# Altium schematic sheet COLLAUDO.SchDoc
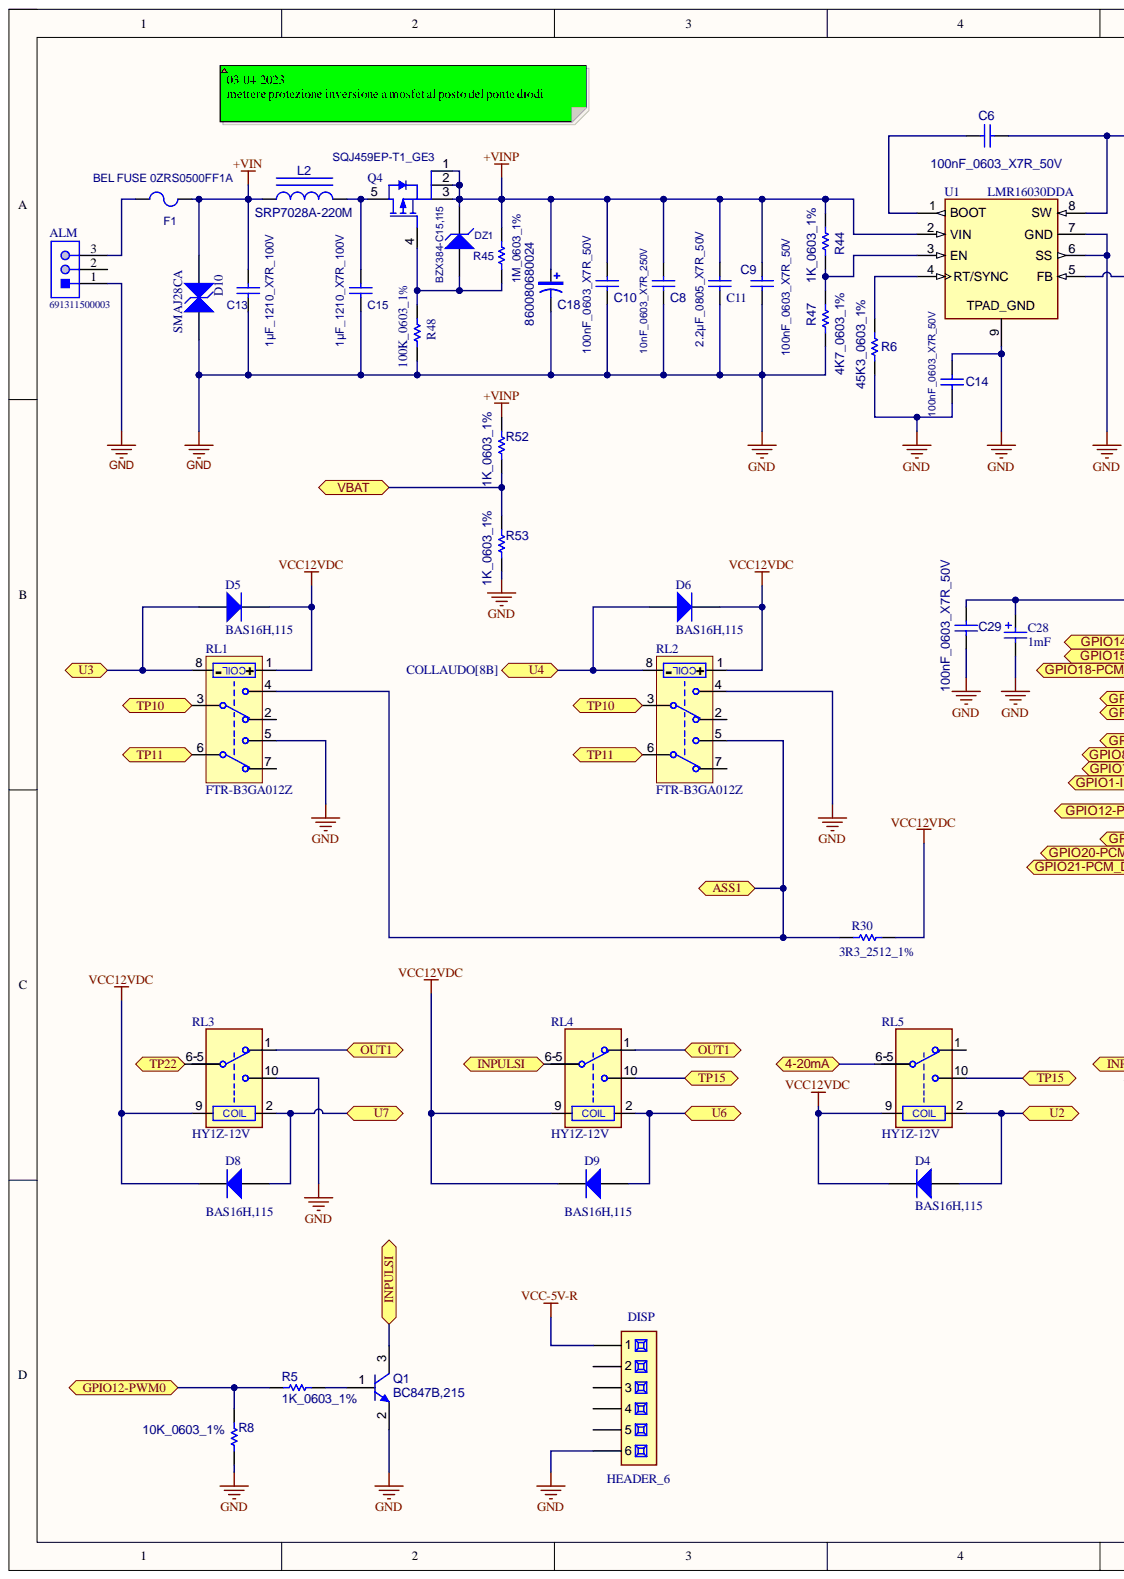
[diagram p0: COLLAUDO.SchDoc, left]
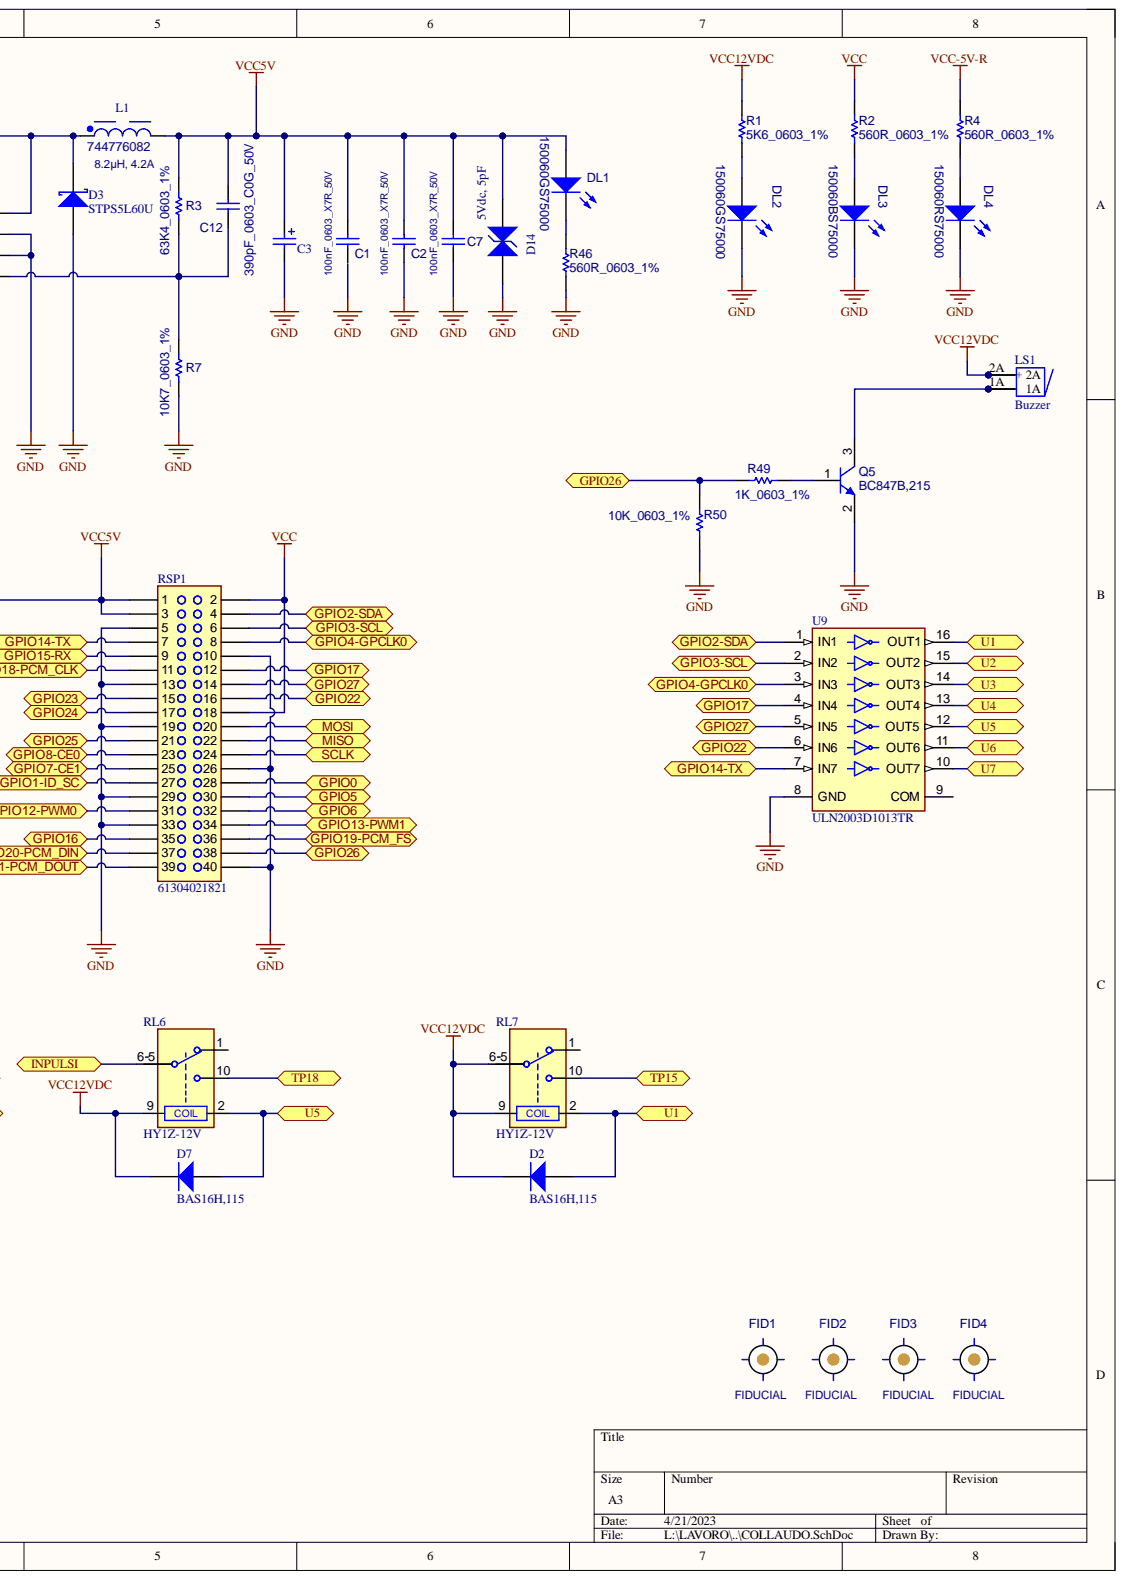
[diagram p1: COLLAUDO.SchDoc, right]

## Parts
ALM  691311500003  [691311500003]
C1  100nF_0603_X7R_50V  [C_0603]
C2  100nF_0603_X7R_50V  [C_0603]
C3  100µF  [WCAP-PSLP_D5H5.5]  (value not drawn)
C6  100nF_0603_X7R_50V  [C_0603]
C7  100nF_0603_X7R_50V  [C_0603]
C8  10nF_0603_X7R_250V  [C_0603]
C9  100nF_0603_X7R_50V  [C_0603]
C10  100nF_0603_X7R_50V  [C_0603]
C11  2.2µF_0805_X7R_50V  [C_0805]
C12  390pF_0603_C0G_50V  [C_0603]
C13  1µF_1210_X7R_100V  [C_1210]
C14  100nF_0603_X7R_50V  [C_0603]
C15  1µF_1210_X7R_100V  [C_1210]
C18  860080680024  [CONDENSATORE_ELETTROLITICO_WE_16mmX25mm_P7.5mm]
C28  value 1mF  [WCAP-ASLL_8x10.5]  (hidden comment: 865060153010)
C29  100nF_0603_X7R_50V  [C_0603]
D2  BAS16H,115  [SOD_123FL]
D3  STPS5L60U  [DIODO SMB]
D4  BAS16H,115  [SOD_123FL]
D5  BAS16H,115  [SOD_123FL]
D6  BAS16H,115  [SOD_123FL]
D7  BAS16H,115  [SOD_123FL]
D8  BAS16H,115  [SOD_123FL]
D9  BAS16H,115  [SOD_123FL]
D10  SMAJ28CA  [DIODO_SMA_NP]
D14  5Vdc, 5pF  Capacitance: 5pF  [WE-VE_0603]
DISP  HEADER_6  [PIN_STRIP_6_POLI_P2.54mm]
DL1  150060GS75000  [LED 0603]
DL2  150060GS75000  [LED 0603]
DL3  150060BS75000  [LED 0603]
DL4  150060RS75000  [LED 0603]
DZ1  BZX384-C15,115  [SOD_323]
F1  BEL FUSE 0ZRS0500FF1A  [PTC LITTLEFUSE AHEF500]
FID1  FIDUCIAL  [FIDUCIAL TONDO CUTOUT]  (no BOM)
FID2  FIDUCIAL  [FIDUCIAL TONDO CUTOUT]  (no BOM)
FID3  FIDUCIAL  [FIDUCIAL TONDO CUTOUT]  (no BOM)
FID4  FIDUCIAL  [FIDUCIAL TONDO CUTOUT]  (no BOM)
L1  744776082  [WE-PD2_1054]
L2  SRP7028A-220M  [INDUTTANZA_BOURNS_SRP7028A]
LS1  Buzzer  [BUZZER-14mm]
Q1  BC847B,215  [SOT-23 3PIN]
Q4  SQJ459EP-T1_GE3  [PowerPAK® SO-8L_SINGLE]
Q5  BC847B,215  [SOT-23 3PIN]
R1  5K6_0603_1%  [R_0603]
R2  560R_0603_1%  [R_0603]
R3  63K4_0603_1%  [R_0603]
R4  560R_0603_1%  [R_0603]
R5  1K_0603_1%  [R_0603]
R6  45K3_0603_1%  [R_0603]
R7  10K7_0603_1%  [R_0603]
R8  10K_0603_1%  [R_0603]
R30  3R3_2512_1%  [R_2512]
R44  1K_0603_1%  [R_0603]
R45  1M_0603_1%  [R_0603]
R46  560R_0603_1%  [R_0603]
R47  4K7_0603_1%  [R_0603]
R48  100K_0603_1%  [R_0603]
R49  1K_0603_1%  [R_0603]
R50  10K_0603_1%  [R_0603]
R52  1K_0603_1%  [R_0603]
R53  1K_0603_1%  [R_0603]
RL1  FTR-B3GA012Z  [RELE' FUJITSU FTR-B3S]
RL2  FTR-B3GA012Z  [RELE' FUJITSU FTR-B3S]
RL3  HY1Z-12V
RL4  HY1Z-12V
RL5  HY1Z-12V
RL6  HY1Z-12V
RL7  HY1Z-12V
RSP1  61304021821
U1  LMR16030DDA  [SOP_8_PAD]
U9  ULN2003D1013TR  [SOP_16]

## Ports
4-20mA, ASS1, GPIO0, GPIO1-ID_SC, GPIO2-SDA, GPIO3-SCL, GPIO4-GPCLK0, GPIO5, GPIO6, GPIO7-CE1, GPIO8-CE0, GPIO12-PWM0, GPIO13-PWM1, GPIO14-TX, GPIO15-RX, GPIO16, GPIO17, GPIO18-PCM_CLK, GPIO19-PCM_FS, GPIO20-PCM_DIN, GPIO21-PCM_DOUT, GPIO22, GPIO23, GPIO24, GPIO25, GPIO26, GPIO27, INPULSI, MISO, MOSI, OUT1, SCLK, TP10, TP11, TP15, TP18, TP22, U1, U2, U3, U4, U5, U6, U7, VBAT

## Nets
+VIN: C13.1 D10.2 F1.2 L2.1
+VINP: C8.2 C9.2 C10.2 C11.2 C18.1 DZ1.2 Q4.1 Q4.2 Q4.3 R44.2 R45.2 R52.2 U1.2(VIN)
GND: ALM.1 C2.1 C3.2 C8.1 C9.1 C10.1 C11.1 C13.2 C14.1 C14.2 C15.2 C18.2 C28.2 C29.1 D3.1 D10.1 D14.1 DISP.6 DL2.2 DL3.2 DL4.2 Q1.2 Q5.2 R6.1 R7.1 R8.2 R46.2 R47.1 R48.2 R50.2 R53.1 RL1.5 RL2.4 RL3.10 RSP1.5 RSP1.10 RSP1.13 RSP1.19 RSP1.26 RSP1.29 RSP1.33 RSP1.40 U1.6(SS) U1.7(GND) U1.9 U9.8(GND)
VCC: R2.1 RSP1.2 RSP1.18
VCC5V: C1.2 C2.2 C3.1 C7.2 C12.2 C28.1 C29.2 D14.2 DL1.1 L1.2 R3.2 RSP1.1 RSP1.3
VCC12VDC: D2.2 D4.2 D5.2 D6.2 D7.2 D8.2 D9.2 LS1.2 LS1.2A R1.1 R30.2 RL1.1(+) RL2.1(+) RL3.9 RL4.9 RL5.9 RL6.9 RL7.6 RL7.9
VCC-5V-R: DISP.1 R4.1
4-20mA: RL5.6 ; port 4-20mA
ASS1: R30.1 RL1.4 RL2.5 ; port ASS1
GPIO0: RSP1.28 ; port GPIO0
GPIO1-ID_SC: RSP1.27 ; port GPIO1-ID_SC
GPIO2-SDA: RSP1.4 U9.1(IN1) ; port GPIO2-SDA
GPIO3-SCL: RSP1.6 U9.2(IN2) ; port GPIO3-SCL
GPIO4-GPCLK0: RSP1.8 U9.3(IN3) ; port GPIO4-GPCLK0
GPIO5: RSP1.30 ; port GPIO5
GPIO6: RSP1.32 ; port GPIO6
GPIO7-CE1: RSP1.25 ; port GPIO7-CE1
GPIO8-CE0: RSP1.23 ; port GPIO8-CE0
GPIO12-PWM0: R5.1 R8.1 RSP1.31 ; port GPIO12-PWM0
GPIO13-PWM1: RSP1.34 ; port GPIO13-PWM1
GPIO14-TX: RSP1.7 U9.7(IN7) ; port GPIO14-TX
GPIO15-RX: RSP1.9 ; port GPIO15-RX
GPIO16: RSP1.35 ; port GPIO16
GPIO17: RSP1.12 U9.4(IN4) ; port GPIO17
GPIO18-PCM_CLK: RSP1.11 ; port GPIO18-PCM_CLK
GPIO19-PCM_FS: RSP1.36 ; port GPIO19-PCM_FS
GPIO20-PCM_DIN: RSP1.37 ; port GPIO20-PCM_DIN
GPIO21-PCM_DOUT: RSP1.39 ; port GPIO21-PCM_DOUT
GPIO22: RSP1.16 U9.6(IN6) ; port GPIO22
GPIO23: RSP1.15 ; port GPIO23
GPIO24: RSP1.17 ; port GPIO24
GPIO25: RSP1.21 ; port GPIO25
GPIO26: R49.1 R50.1 RSP1.38 ; port GPIO26
GPIO27: RSP1.14 U9.5(IN5) ; port GPIO27
INPULSI: Q1.3 RL4.6 RL6.6 ; port INPULSI
MISO: RSP1.22 ; port MISO
MOSI: RSP1.20 ; port MOSI
OUT1: RL3.1 RL4.1 ; port OUT1
SCLK: RSP1.24 ; port SCLK
TP10: RL1.3 RL2.3 ; port TP10
TP11: RL1.6 RL2.6 ; port TP11
TP15: RL4.10 RL5.10 RL7.10 ; port TP15
TP18: RL6.10 ; port TP18
TP22: RL3.6 ; port TP22
U1: D2.1 RL7.2(COIL) U9.16(OUT1) ; port U1
U2: D4.1 RL5.2(COIL) U9.15(OUT2) ; port U2
U3: D5.1 RL1.8(-) U9.14(OUT3) ; port U3
U4: D6.1 RL2.8(-) U9.13(OUT4) ; port U4
U5: D7.1 RL6.2(COIL) U9.12(OUT5) ; port U5
U6: D9.1 RL4.2(COIL) U9.11(OUT6) ; port U6
U7: D8.1 RL3.2(COIL) U9.10(OUT7) ; port U7
VBAT: R52.1 R53.2 ; port VBAT
NetALM_3: ALM.3 F1.1
NetC6_1: C6.1 D3.2 L1.1 U1.8(SW)
NetC6_2: C6.2 U1.1(BOOT)
NetC12_1: C12.1 R3.1 R7.2 U1.5(FB)
NetC15_1: C15.1 L2.2 Q4.5
NetDL1_2: DL1.2 R46.1
NetDL2_1: DL2.1 R1.2
NetDL3_1: DL3.1 R2.2
NetDL4_1: DL4.1 R4.2
NetDZ1_1: DZ1.1 Q4.4 R45.1 R48.1
NetLS1_1: LS1.1 LS1.1A Q5.3
NetQ1_1: Q1.1 R5.2
NetQ5_1: Q5.1 R49.2
NetR6_2: R6.2 U1.4(RT/SYNC)
NetR44_1: R44.1 R47.2 U1.3(EN)

Not connected: ALM 2; C1 1; C7 1; DISP 2 3 4 5; RL1 2 7; RL2 2 7; RL3 5; RL4 5; RL5 1 5; RL6 1 5; RL7 1 5; U9 9(COM)

## Library data of the parts
ALM = 691311500003: Serie 311 - 5.08mm Open Vertical PCB Header WR-TBL, 3 pin; Gender: Male; Pin Number: 3; Component Kind: Standard; ComponentLink1Description: Manufacturer; ComponentLink1URL: http://www.we-online.de; ComponentLink2Description: Datasheet; ComponentLink2URL: http://katalog.we-online.de/em/datasheet/6913115000xx.pdf; Library Name: Pluggable PCB System WR-TBL_5.08 mm.SchLib; Mounting Technology: THT; Operating Temperature: -40 to 105 degC; Packaging: Box; Part Number: 691311500003; Pitch: 5.08 mm; Published Date: July 2018; Publisher: Wurth Elektronik
C1 C2 C6 C7 C9 C10 C14 C29 = 100nF_0603_X7R_50V: CERAMIC CAPACITOR ±15% (-55° +125°) 50V -  0603; Manufacturer_Name: GENERICO; Codice_Magazzino: C0603-100N-X7R-50V; MOUNTING_TECHNOLOGY: SMD; Component Kind: Standard; Library Name: LIBRERIA STARTB4 COMPONENTI PASSIVI.SchLib
C3 = 875105242010: WCAP-PSLP Aluminum Polymer Capacitor, V-Chip SMT, D5 x H5.5mm, 100µF, 10V; Manufacturer: Wurth Elektronik; Capacitance Tolerance: +/-20%; Dissipation Factor: 8%; Endurance: 2000h; ESR: 30mΩ; Leakage Current: 300µA; Ripple Current: 1970mA; Technical Reference: PSEC055101M010DVCTBB000; Capacitance: 100µF; Category: Aluminum Polymer Capacitors; Component Kind: Standard; ComponentLink1Description: Website Link; ComponentLink1URL: https://www.we-online.com/redexpert/article/875105242010?ad; ComponentLink2Description: Datasheet Link; ComponentLink2URL: https://www.we-online.com/redexpert/spec/875105242010?ad; Diameter: 5mm; Height: 5.5mm; Library Name: WCAP-PSLP.SchLib; Manufacturer Part Number: 875105242010; Match Code: WCAP-PSLP; Mount: Surface Mount; Operating Temperature Max: 105°C; Operating Temperature Min: -55°C; Packaging: Tape and Reel; Rated Voltage (DC): 10V
C8 = 10nF_0603_X7R_250V: CERAMIC CAPACITOR ±15% (-55° +125°) 250V -  0603; Manufacturer_Name: GENERICO; Codice_Magazzino: C0603-10N-X7R-250V; MOUNTING_TECHNOLOGY: SMD; Component Kind: Standard; Library Name: LIBRERIA STARTB4 COMPONENTI PASSIVI.SchLib
C11 = 2.2µF_0805_X7R_50V: CERAMIC CAPACITOR ±15% (-55° +125°) 50V -  0805; Manufacturer_Name: GENERICO; Codice_Magazzino: C0805-2U2-X7R-50V; MOUNTING_TECHNOLOGY: SMD; Component Kind: Standard; Library Name: LIBRERIA STARTB4 COMPONENTI PASSIVI.SchLib
C12 = 390pF_0603_C0G_50V: CERAMIC CAPACITOR ±5% (-55° +125°) 50V -  0603; Manufacturer_Name: GENERICO; Codice_Magazzino: C0603-390P-COG-50V; MOUNTING_TECHNOLOGY: SMD; Component Kind: Standard; Library Name: LIBRERIA STARTB4 COMPONENTI PASSIVI.SchLib
C13 C15 = 1µF_1210_X7R_100V: CERAMIC CAPACITOR ±15% (-55° +125°) 100V -  1210; Manufacturer_Name: GENERICO; Codice_Magazzino: C1210-1U-X7R-100V; MOUNTING_TECHNOLOGY: SMD; Component Kind: Standard; Library Name: LIBRERIA STARTB4 COMPONENTI PASSIVI.SchLib
C18 = 860080680024: CAP ALUM 1000UF 20% 50V - RIPPLE CURRENT 3A - 105°- 5000H; Manufacturer_Name: WE; MOUNTING_TECHNOLOGY: THT; Codice_Magazzino: 860080680024; Component Kind: Standard; Library Name: LIBRERIA STARTB4 COMPONENTI PASSIVI.SchLib
C28 = 865060153010: WCAP-ASLL Aluminum Electrolytic Capacitors, size Ф8x10.5mm, 6.3V DC, 1mF; Rated Voltage: 6.3V DC; Component Kind: Standard; ComponentLink1Description: Manufacturer; ComponentLink1URL: http://www.we-online.de; ComponentLink2Description: Datasheet; ComponentLink2URL: http://katalog.we-online.de/pbs/datasheet/865060153010.pdf; Library Name: WCAP-ASLL.SchLib; Life Cycle: 5000h; Operating Temperature: -55 °C up to +105 °C; Part Number: 865060153010; Published Date: June 2017; Size: Ф8x10.5mm; Publisher: Wurth Elektronik
D2 D4-D9 = BAS16H,115: High-speed switching diode 100V 215mA SOD123F; Manufacturer_Name: NXP; MOUNTING_TECHNOLOGY: SMD; Codice_Magazzino: BAS16H,115; Component Kind: Standard; Library Name: LIBRERIA STARTB4 COMPONENTI ATTIVI.SchLib
D3 = STPS5L60U: Diodo Schottky  60V 5A SMB; Manufacturer_Name: ST; MOUNTING_TECHNOLOGY: SMD; Codice_Magazzino: STPS5L60U; Component Kind: Standard; Library Name: LIBRERIA STARTB4 COMPONENTI ATTIVI.SchLib
D10 = SMAJ28CA: TVS DIODE BIDIRECTIONAL 28VWM 45.4VC 400 W (10/1000 μs); Manufacturer_Name: ST; MOUNTING_TECHNOLOGY: SMD; Codice_Magazzino: SMAJ28CA; Component Kind: Standard; Library Name: LIBRERIA STARTB4 COMPONENTI ATTIVI.SchLib
D14 = 82356050050: WE-VE ESD Suppressor, size 0603, 5Vdc, 5pF; Clamp Voltage: 75V; ESD Voltage air discharge: 15kV; Leakage Current: 1uA; Component Kind: Standard; ComponentLink1Description: Manufacturer; ComponentLink1URL: http://www.we-online.de; ComponentLink2Description: Datasheet; ComponentLink2URL: https://katalog.we-online.com/pbs/datasheet/82356050050.pdf; Library Name: WE-VE_0603.SchLib; Mounting Technology: SMT; Operating Temperature: -40 to +85degC; Packaging: Tape and Reel; Part Number: 82356050050; Published Date: June 2019; Size: 0603; Working Voltage: 5Vdc; Publisher: Wurth Elektronik
DISP = HEADER_6: CONNETTORE PIN STRIP 6 VIE - PASSO 2.54mm; Manufacturer_Name: GENERICO; Codice_Magazzino: LE008006-R; MOUNTING_TECHNOLOGY: THT; Component Kind: Standard; Library Name: LIBRERIA STARTB4 COMPONENTI ELETTROMECCANICI.SchLib
DL1 DL2 DL4 = 150060RS75000: LED ROSSO  SMD, 0603 [1608 metrico], 30 mA, 2 V, 625 nm; Manufacturer_Name: WE; Codice_Magazzino: 150060RS75000; Component Kind: Standard; MOUNTING_TECHNOLOGY: SMD; Library Name: LIBRERIA STARTB4 COMPONENTI ATTIVI.SchLib
DL3 = 150060bS75000: LED BLU SMD, 0603 [1608 metrico], 20 mA, 3,2 V - 465 nm; Manufacturer_Name: WE; Codice_Magazzino: 150060BS75000; Component Kind: Standard; MOUNTING_TECHNOLOGY: SMD; Library Name: LIBRERIA STARTB4 COMPONENTI ATTIVI.SchLib
DZ1 = BZX384-C15,115: Diode Zener 15V 300 mW ±5%  SOD323; Manufacturer_Name: NXP; MOUNTING_TECHNOLOGY: SMD; Codice_Magazzino: BZX384-C15; Component Kind: Standard; Library Name: LIBRERIA STARTB4 COMPONENTI ATTIVI.SchLib
F1 = BOUR-FUSE-2: Multifuse, SMD 5A; Manufacturer: Bourns; Manufacturer Part Number 1: MF-SM185/33-2; PartNumber: MF-SM250; Approvals: UL,CSA,TUV; Current Rating: 40A; Hold Current: 1.8A; Junction Temperature: -40 to 85 degC; Nominal Voltage: 15 V; Operating Supply Voltage: 33V; Power Dissipation: 1.9 W; Resistance: 150mR; Time to Trip: 5s; Trip Current: 3.6A; Case/Package: SMD/SMT; Component Kind: Standard; ComponentLink1Description: Manufacturer URL; ComponentLink1URL: http://www.bourns.com/; ComponentLink2Description: Datasheet; ComponentLink2URL: http://www.bourns.com/data/global/pdfs/mfsm.pdf; ComponentLink3Description: https://datasheet.ciiva.com/329/mfsm-329232.pdf?src-supplier=Digi-Key; ComponentLink3URL: https://datasheet.ciiva.com/329/mfsm-329232.pdf?src-supplier=Digi-Key; ComponentLink4Description: https://datasheet.ciiva.com/32438/mf-sm-series-eng-tds-32438730.pdf?src-supplier=Distrelec; ComponentLink4URL: https://datasheet.ciiva.com/32438/mf-sm-series-eng-tds-32438730.pdf?src-supplier=Distrelec; ComponentLink5Description: https://datasheet.ciiva.com/329/mfsm-329283.pdf?src-supplier=Digi-Key; ComponentLink5URL: https://datasheet.ciiva.com/329/mfsm-329283.pdf?src-supplier=Digi-Key; ComponentLink6Description: https://datasheet.ciiva.com/1228/1786006-1228436.pdf?src-supplier=Newark; ComponentLink6URL: https://datasheet.ciiva.com/1228/1786006-1228436.pdf?src-supplier=Newark; ComponentLink7Description: https://datasheet.ciiva.com/30162/505mfsm-30162680.pdf?src-supplier=Onlinecomponents.com; ComponentLink7URL: https://datasheet.ciiva.com/30162/505mfsm-30162680.pdf?src-supplier=Onlinecomponents.com; ComponentLink8Description: https://datasheet.ciiva.com/1274/1786006-1274862.pdf?src-supplier=Element14; ComponentLink8URL: https://datasheet.ciiva.com/1274/1786006-1274862.pdf?src-supplier=Element14; ComponentLink9Description: https://datasheet.ciiva.com/3019/mfsm-3019678.pdf?src-supplier=Avnet; ComponentLink9URL: https://datasheet.ciiva.com/3019/mfsm-3019678.pdf?src-supplier=Avnet; ComponentLink10Description: https://datasheet.ciiva.com/1274/1786006-1274863.pdf?src-supplier=Element14; ComponentLink10URL: https://datasheet.ciiva.com/1274/1786006-1274863.pdf?src-supplier=Element14; Contact Plating: Tin; DatasheetVersion: Rev. P, 11/2010; Height: 3mm; Length: 9.5mm; Library Name: Miscellaneous Devices.IntLib; Manufacturer 1: Bourns; Max Current Rating: 40A; Max Operating Temperature: 85°C; Min Operating Temperature: -40°C; Mount: Surface Mount; Mounting Technology: Surface Mount; Number of Terminals: 2; Package Quantity: 1500; PackageDescription: 2-Pin SMT Fuse, 9.5 x 6.71 mm Body; PackageReference: MF-SM250; Packaging: Tape and Reel; Pricing 1: 1=0,65000, 5=0,63400, 10=0,61400, 50=0,51640, 100=0,42870, 250=0,38972, 500=0,33124 (USD); Radiation Hardening: No; REACH SVHC: No SVHC; RoHS: Yes; Stock 1: 1907; Supplier 1: Digi-Key; Supplier Part Number 1: MF-SM185/33-2CT-ND; Terminal Material: Brass; Termination: SMD/SMT; Voltage Rating: 33V; Voltage Rating (DC): 33V; Width: 6.71mm
FID1-FID4 = FIDUCIAL: CONNETTORE AMPMODU II - 5A Max Current - 3 VIE - PASSO 2.54mm; Manufacturer_Name: PCB; Codice_Magazzino: FIDUCIAL; MOUNTING_TECHNOLOGY: PCB; Library Name: LIBRERIA STARTB4 COMPONENTI ELETTROMECCANICI.SchLib
L1 = 744776082: WE-PD2 SMT Power Inductor, size 1054, 8.2µH, 4.2A, 0.026Ω; Manufacturer_Name: WE; MOUNTING_TECHNOLOGY: SMD; Codice_Magazzino: 744776082; Component Kind: Standard; VALORE: 8.2µH, 4.2A; Library Name: LIBRERIA STARTB4 COMPONENTI PASSIVI.SchLib
L2 = SRP7028A-220M: Power Shielded Inductor 22 uH, ± 20% - 2A 0.19Ohm DCR - -40°C ~ 150°C - AECQ200; Manufacturer_Name: BOURNS; MOUNTING_TECHNOLOGY: SMD; Codice_Magazzino: SRP7028A-220M; Component Kind: Standard; Library Name: LIBRERIA STARTB4 COMPONENTI PASSIVI.SchLib
LS1 = Buzzer: Magnetic Transducer Buzzer; Component Kind: Standard; ComponentLink1Description: Manufacturer Link; ComponentLink1URL: http://www.megastar.com/linecard/buzzmode/index.php; DatasheetVersion: 17-Dec-2003; LatestRevisionDate: 17-Dec-2003; LatestRevisionNote: Schematic revised, PCB Footprint replaced.; PackageDescription: Magnetic Transducer Buzzer; PackageReference: ABSM-1574; Published: 24-Mar-1999; Library Name: HSW.SchLib; Publisher: Altium Limited
Q1 Q5 = BC847B,215: General Purpose transistor,  NPN 45 V 100 mA 100MHz 250 mW, 3-Pin SOT-23; Manufacturer_Name: NXP; MOUNTING_TECHNOLOGY: SMD; Codice_Magazzino: BC847B,215; Component Kind: Standard; Library Name: LIBRERIA STARTB4 COMPONENTI ATTIVI.SchLib
Q4 = SQJ459EP-T1_GE3: Mosfet P-Channel - Vds 60V - Vgs ±20V - 52A -55/+175 °C - AEC-Q101; Manufacturer_Name: VISHAY; MOUNTING_TECHNOLOGY: SMD; Codice_Magazzino: SQJ459EP-T1_GE3; Component Kind: Standard; Library Name: LIBRERIA STARTB4 COMPONENTI ATTIVI.SchLib
R1 = 5K6_0603_1%: RESISTOR  0,1W, 1/10W ±1% 0603; Manufacturer_Name: GENERICO; Codice_Magazzino: R0603-5K6-F; MOUNTING_TECHNOLOGY: SMD; Component Kind: Standard; Library Name: LIBRERIA STARTB4 COMPONENTI PASSIVI.SchLib
R2 R4 R46 = 560R_0603_1%: RESISTOR  0,1W, 1/10W ±1% 0603; Manufacturer_Name: GENERICO; Codice_Magazzino: R0603-560R-F; MOUNTING_TECHNOLOGY: SMD; Component Kind: Standard; Library Name: LIBRERIA STARTB4 COMPONENTI PASSIVI.SchLib
R3 = 63K4_0603_1%: RESISTOR  0,1W, 1/10W ±1% 0603; Manufacturer_Name: GENERICO; Codice_Magazzino: R0603-63K4-F; MOUNTING_TECHNOLOGY: SMD; Component Kind: Standard; Library Name: LIBRERIA STARTB4 COMPONENTI PASSIVI.SchLib
R5 R44 R49 R52 R53 = 1K_0603_1%: RESISTOR  0,1W, 1/10W ±1% 0603; Manufacturer_Name: GENERICO; Codice_Magazzino: R0603-1K-F; MOUNTING_TECHNOLOGY: SMD; Component Kind: Standard; Library Name: LIBRERIA STARTB4 COMPONENTI PASSIVI.SchLib
R6 = 45K3_0603_1%: RESISTOR  0,1W, 1/10W ±1% 0603; Manufacturer_Name: GENERICO; Codice_Magazzino: R0603-45K3-F; MOUNTING_TECHNOLOGY: SMD; Component Kind: Standard; Library Name: LIBRERIA STARTB4 COMPONENTI PASSIVI.SchLib
R7 = 10K7_0603_1%: RESISTOR  0,1W, 1/10W ±1% 0603; Manufacturer_Name: GENERICO; Codice_Magazzino: R0603-10K7-F; MOUNTING_TECHNOLOGY: SMD; Component Kind: Standard; Library Name: LIBRERIA STARTB4 COMPONENTI PASSIVI.SchLib
R8 R50 = 10K_0603_1%: RESISTOR  0,1W, 1/10W ±1% 0603; Manufacturer_Name: GENERICO; Codice_Magazzino: R0603-10K-F; MOUNTING_TECHNOLOGY: SMD; Component Kind: Standard; Library Name: LIBRERIA STARTB4 COMPONENTI PASSIVI.SchLib
R30 = 3R3_2512_1%: RESISTOR  1W  ±1% 2512; Manufacturer_Name: GENERICO; Codice_Magazzino: R2512-3R3-F; MOUNTING_TECHNOLOGY: SMD; Component Kind: Standard; Library Name: LIBRERIA STARTB4 COMPONENTI PASSIVI.SchLib
R45 = 1M_0603_1%: RESISTOR  0,1W, 1/10W ±1% 0603; Manufacturer_Name: GENERICO; Codice_Magazzino: R0603-1M-F; MOUNTING_TECHNOLOGY: SMD; Component Kind: Standard; Library Name: LIBRERIA STARTB4 COMPONENTI PASSIVI.SchLib
R47 = 4K7_0603_1%: RESISTOR  0,1W, 1/10W ±1% 0603; Manufacturer_Name: GENERICO; Codice_Magazzino: R0603-4K7-F; MOUNTING_TECHNOLOGY: SMD; Component Kind: Standard; Library Name: LIBRERIA STARTB4 COMPONENTI PASSIVI.SchLib
R48 = 100K_0603_1%: RESISTOR  0,1W, 1/10W ±1% 0603; Manufacturer_Name: GENERICO; Codice_Magazzino: R0603-100K-F; MOUNTING_TECHNOLOGY: SMD; Component Kind: Standard; Library Name: LIBRERIA STARTB4 COMPONENTI PASSIVI.SchLib
RL1 RL2 = FTR-B3GA012Z: 2 POLES - 2A (Low Profile Signal Relay) (DPDT-NO, NC) - COIL 12V; Manufacturer_Name: FUJITSU; MOUNTING_TECHNOLOGY: SMD; Codice_Magazzino: FTR-B3GA012Z; Component Kind: Standard; Library Name: LIBRERIA STARTB4 COMPONENTI PASSIVI.SchLib
RL3-RL7 = HY1Z-12V: 1 POLES - 1A (Low Profile Signal Relay) (DPDT-NO, NC) - COIL 12V -  -40°C~70°C; Manufacturer_Name: PANASONIC; MOUNTING_TECHNOLOGY: THT; Codice_Magazzino: HY1Z-12V; Component Kind: Standard; Library Name: LIBRERIA STARTB4 COMPONENTI PASSIVI.SchLib
RSP1 = 61304021821: WR-PHD Socket Header, THT, pitch 2.54mm, Dual Row, Vertical, 40p; Manufacturer_Name: WE; Codice_Magazzino: 61304021821; Component Kind: Standard; MOUNTING_TECHNOLOGY: THT; Library Name: LIBRERIA STARTB4 COMPONENTI ELETTROMECCANICI.SchLib
U1 = LMR16030DDA: SIMPLE SWITCHER® 60-V,  Step-Down Converter - 3A  Output current - -40 °C to 125 °C  - SOP8; Manufacturer_Name: TI; MOUNTING_TECHNOLOGY: SMD; Codice_Magazzino: LMR16030DDA; Component Kind: Standard; Library Name: LIBRERIA STARTB4 COMPONENTI ATTIVI.SchLib
U9 = ULN2003D1013TR: High-Voltage, High-Current Darlington Transistor Array, 0.5 A, 50 V - -20 to 70 °C - SOIC_16; Manufacturer_Name: ST; MOUNTING_TECHNOLOGY: SMD; Codice_Magazzino: ULN2003D1013TR; Component Kind: Standard; Library Name: LIBRERIA STARTB4 COMPONENTI ATTIVI.SchLib
